# Altium schematic sheet Sheet1.SchDoc
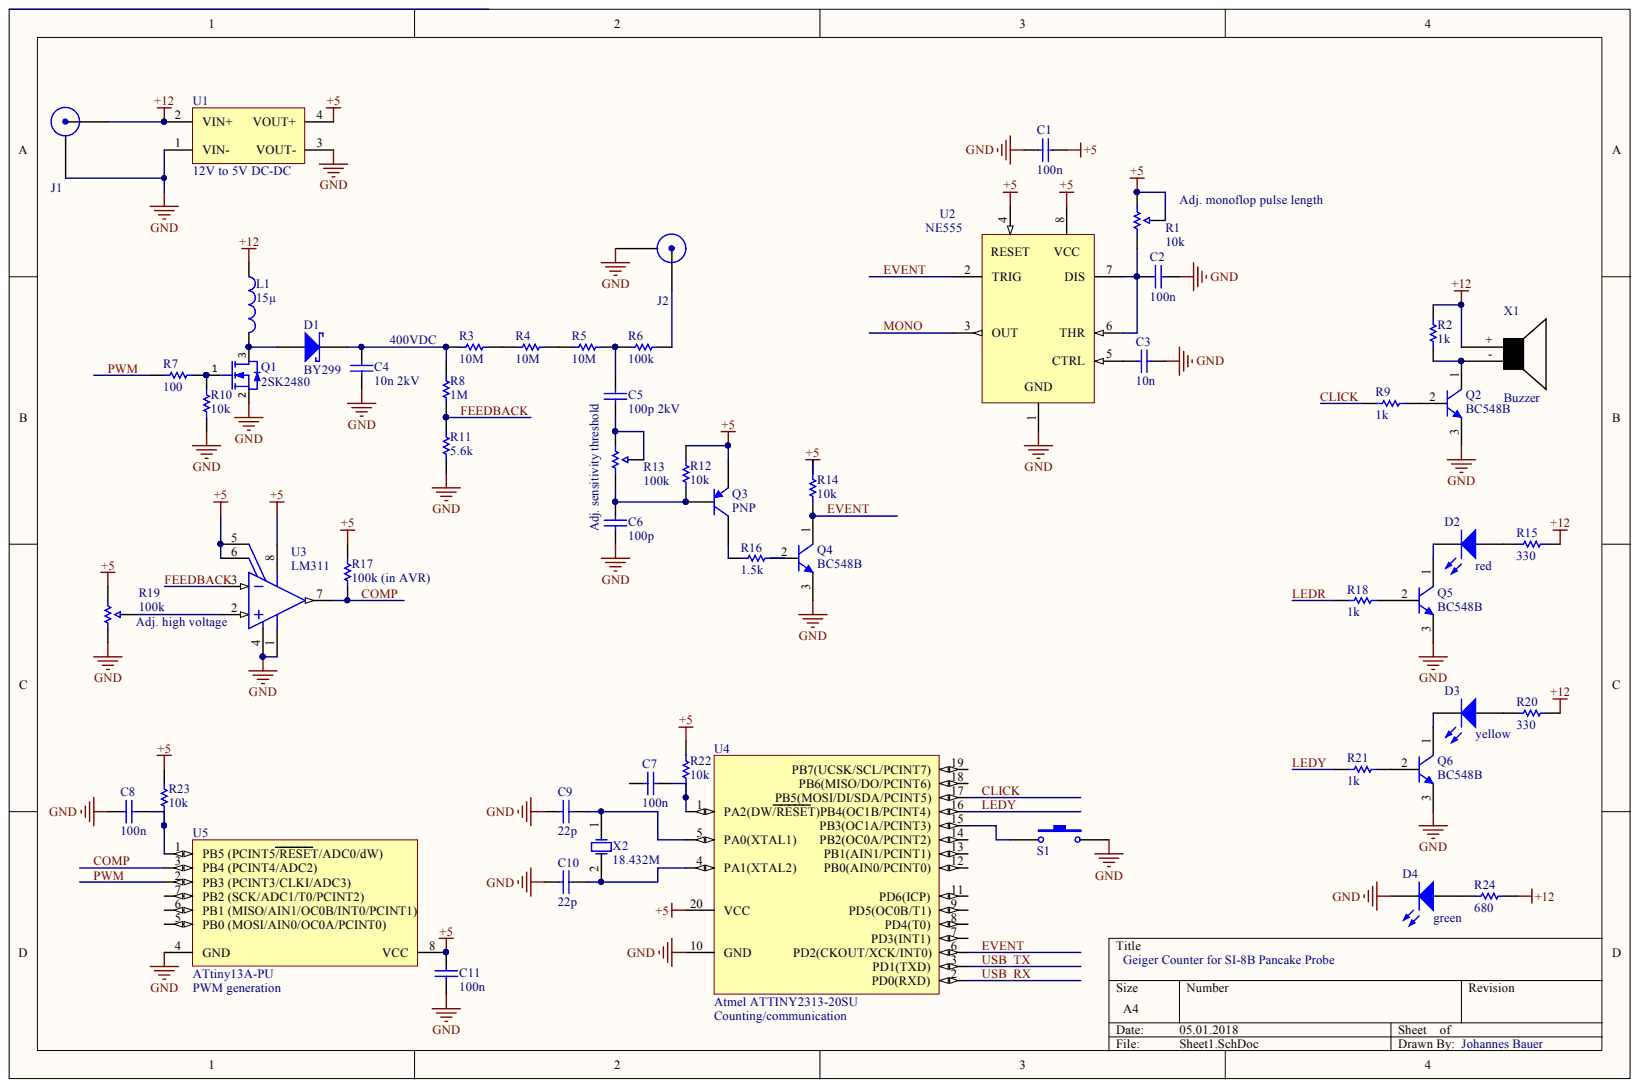
[diagram p0: Sheet1.SchDoc]

## Parts
C1  100n  [CAPC2013X145X50ML20T25]
C2  100n  [CAPC2013X145X50ML20T25]
C3  10n  [CAPC2013X145X50ML20T25]
C4  10n 2kV  [CAPC2013X145X50ML20T25]
C5  100p 2kV  [CAPC2013X145X50ML20T25]
C6  100p  [CAPC2013X145X50ML20T25]
C7  100n  [CAPC2013X145X50ML20T25]
C8  100n  [CAPC2013X145X50ML20T25]
C9  22p  [CAPC2013X145X50ML20T25]
C10  22p  [CAPC2013X145X50ML20T25]
C11  100n  [CAPC2013X145X50ML20T25]
D1  BY299  [PCB-t01urt8kbl9xjuopr1um-1]
D2  red  [PCB-r9altwvwx3huda88quuq-1]
D3  yellow  [PCB-r9altwvwx3huda88quuq-1]
D4  green  [PCB-r9altwvwx3huda88quuq-1]
J1  TE Connectivity 5227699-2  [PCB-578401-1]  (value not drawn)
J2  TE Connectivity 5227699-2  [PCB-578401-1]  (value not drawn)
L1  15µ  [PCB-ilvywpa6bs8jitgkp8xa-1]
Q1  2SK2480  [PCB-2559974-1]
Q2  BC548B  [ONSC-TO-92-3-29-11]
Q3  PNP  [ONSC-SOT-23-3-318-08_V]
Q4  BC548B  [ONSC-TO-92-3-29-11]
Q5  BC548B  [ONSC-TO-92-3-29-11]
Q6  BC548B  [ONSC-TO-92-3-29-11]
R1  10k  [PCB-fwyp7l2njgjc0ljzabsa-1]
R2  1k  [RESC2013X50X30LL20T20]
R3  10M  [RESC2013X50X30LL20T20]
R4  10M  [RESC2013X50X30LL20T20]
R5  10M  [RESC2013X50X30LL20T20]
R6  100k  [RESC2013X50X30LL20T20]
R7  100  [RESC2013X50X30LL20T20]
R8  1M  [RESC2013X50X30LL20T20]
R9  1k  [RESC2013X50X30LL20T20]
R10  10k  [RESC2013X50X30LL20T20]
R11  5.6k  [RESC2013X50X30LL20T20]
R12  10k  [RESC2013X50X30LL20T20]
R13  100k  [PCB-fwyp7l2njgjc0ljzabsa-1]
R14  10k  [RESC2013X50X30LL20T20]
R15  330  [RESC2013X50X30LL20T20]
R16  1.5k  [RESC2013X50X30LL20T20]
R17  100k (in AVR)  [RESC2013X50X30LL20T20]
R18  1k  [RESC2013X50X30LL20T20]
R19  100k  [PCB-fwyp7l2njgjc0ljzabsa-1]
R20  330  [RESC2013X50X30LL20T20]
R21  1k  [RESC2013X50X30LL20T20]
R22  10k  [RESC2013X50X30LL20T20]
R23  10k  [RESC2013X50X30LL20T20]
R24  680  [RESC2013X50X30LL20T20]
S1  (no value)  [SW2_5R6_5R5x3R1]
U1  12V to 5V DC-DC  [PCB-r43aylk1y2or0wdlr2x7-1]
U2  NE555
U3  LM311  [ONSC-SOIC-8-751-07_V]
U4  Atmel ATTINY2313-20SU  [SOIC20-1.27-12.5X7.5MM]
U5  ATtiny13A-PU  [8P3]
X1  Buzzer  [2P-7.6-D12X6.5H]
X2  18.432M  [PCB-fygqjweg5fext21t9isa-1]

## Nets
+5: C1.2 C11.1 Q3.3 R1.1 R1.2 R12.2 R14.2 R17.2 R19.1 R22.2 R23.2 U1.4(VOUT+) U2.4 U2.8 U3.5 U3.6 U3.8 U4.20(VCC) U5.8(VCC)
+12: J1.1 L1.1 R2.2 R15.1 R20.1 R24.1 U1.2(VIN+) X1.+
GND: C1.1 C2.2 C3.2 C4.2 C6.2 C8.2 C9.2 C10.2 C11.2 D4.1 J1.2 J2.2 Q1.2 Q2.3 Q4.3 Q5.3 Q6.3 R10.2 R11.2 R19.3 S1.2 U1.1(VIN-) U1.3(VOUT-) U2.1 U3.1 U3.4 U4.10(GND) U5.4(GND)
CLICK: R9.2 U4.17(PB5(MOSI/DI/SDA/PCINT5))
COMP: R17.1 U3.7 U5.3(PB4_(PCINT4/ADC2))
EVENT: Q4.1 R14.1 U2.2(TRIG) U4.6(PD2(CKOUT/XCK/INT0))
FEEDBACK: R8.2 R11.1 U3.3
LEDR: R18.2
LEDY: R21.2 U4.16(PB4(OC1B/PCINT4))
MONO: U2.3(OUT)
PWM: R7.2 U5.2(PB3_(PCINT3/CLKI/ADC3))
USB_RX: U4.2(PD0(RXD))
USB_TX: U4.3(PD1(TXD))
NetC2_1: C2.1 R1.3 U2.6(THR) U2.7(DIS)
NetC3_1: C3.1 U2.5(CTRL)
NetC4_1: C4.1 D1.2 R3.2 R8.1
NetC5_1: C5.1 R5.1 R6.2
NetC5_2: C5.2 R13.1 R13.2
NetC6_1: C6.1 Q3.2 R12.1 R13.3
NetC7_1: C7.1 R22.1 U4.1(PA2(DW/RESET))
NetC8_1: C8.1 R23.1 U5.1(PB5_(PCINT5/RESET/ADC0/dW))
NetC9_1: C9.1 U4.5(PA0(XTAL1)) X2.1
NetC10_1: C10.1 U4.4(PA1(XTAL2)) X2.2
NetD1_1: D1.1 L1.2 Q1.3
NetD2_1: D2.1 Q5.1
NetD2_2: D2.2 R15.2
NetD3_1: D3.1 Q6.1
NetD3_2: D3.2 R20.2
NetD4_2: D4.2 R24.2
NetJ2_1: J2.1 R6.1
NetQ1_1: Q1.1 R7.1 R10.1
NetQ2_1: Q2.1 R2.1 X1.-
NetQ2_2: Q2.2 R9.1
NetQ3_1: Q3.1 R16.2
NetQ4_2: Q4.2 R16.1
NetQ5_2: Q5.2 R18.1
NetQ6_2: Q6.2 R21.1
NetR3_1: R3.1 R4.2
NetR4_1: R4.1 R5.2
NetR19_2: R19.2 U3.2
NetS1_1: S1.1 U4.15(PB3(OC1A/PCINT3))
N00011:

Not connected: C7 2; U4 7(PD3(INT1)) 8(PD4(T0)) 9(PD5(OC0B/T1)) 11(PD6(ICP)) 12(PB0(AIN0/PCINT0)) 13(PB1(AIN1/PCINT1)) 14(PB2(OC0A/PCINT2)) 18(PB6(MISO/DO/PCINT6)) 19(PB7(UCSK/SCL/PCINT7)); U5 5(PB0_(MOSI/AIN0/OC0A/PCINT0)) 6(PB1_(MISO/AIN1/OC0B/INT0/PCINT1)) 7(PB2_(SCK/ADC1/T0/PCINT2))

## Library data of the parts
C1-C11 = C0805C224J5RACTU: Multilayer Ceramic Capacitors MLCC - SMD/SMT 50volts 0.22uF X7R 5%; Capacitance: 2.2e-07 F; Lead-Free Status: R; Voltage Rating (DC): 50.0 V; Capacitance Tolerance: ±5%; Case/Package: 0805; Component Kind: Standard; Dielectric Characteristic: X7R; Dielectric Material: Ceramic; Library Name: Octopart library; Mounting Style: Surface Mount; OctopartID: dc57145de2800390; Octopart Url: https://octopart.com/c0805c224j5ractu-kemet-16784305; RoHS: Compliant
D1 = MSS2P3-M3/89A: MSS2P3 Series 30 V 2 A Surface Mount Schottky Barrier Rectifier - MicroSMP; Component Kind: Standard; Library Name: Octopart library; OctopartID: ce170593b09f11d0
D2-D4 = SML-D12D8WT86: SML-D12 Series Orange 0603 Diffused 100 mcd 2.2V Surface Mount LED; Forward Voltage: 2.20 V; Luminous Intensity: 100 mcd; Case/Package: 0603; Color: Orange; Component Kind: Standard; Library Name: Octopart library; Manufacturer Part Number: SML-D12D8WT86; OctopartID: a47e6df945417bb9; Octopart URL: http://octopart.com/sml-d12d8wt86-rohm-9290841; Packaging: Cut Tape (CT)
J1 J2 = 5227699-2: CONN JACK BNC VERT 50 OHM PCB AU; ELV Compliant: Compliant; Gender: Male; Lead-Free Status: Lead Free; Component Kind: Standard; Contact Material: Phosphor Bronze; Contact Plating: Gold; Library Name: Octopart library; Lifecycle Status: Active; OctopartID: aab361e385ae7574; Octopart Url: https://octopart.com/5227699-2-te+connectivity-39455355; Orientation: Vertical; Packaging: Tube; REACH SVHC Compliance: No SVHC; RoHS: Compliant
L1 = ELJLC100KF: Ind Power Chip Molded Wirewound 10uH 10% 1MHz Ferrite 240mA 1008 T/R; Inductance: 10.0 µH; Component Kind: Standard; Library Name: Octopart library; Lifecycle Status: Not Listed by Manufacturer; Manufacturer Part Number: ELJLC100KF; Number of Pins: 2; OctopartID: e8262003ce8837e1; Packaging: Cut Tape (CT); REACH SVHC Compliance: No SVHC; RoHS: Compliant
Q1 = CMP-2559974-6: Trans MOSFET N-CH 25V 5.8A 3-Pin SOT-23 T/R; Part Family: IRFML8244; Lead-Free Status: Lead Free; Polarity: N-Channel; Power Dissipation: 1.25 W; Component Kind: Standard; Library Name: CommunityVault; Lifecycle Status: Active; Mounting Style: Surface Mount; Number of Pins: 3; OctopartID: 10b1d89ad6afcf76; Octopart Url: https://octopart.com/irfml8244trpbf-international+rectifier-18998136; Packaging: Cut Tape (CT); REACH SVHC Compliance: No SVHC; RoHS: Compliant
Q2 Q4-Q6 = BC548B: Amplifier Transistor, NPN Silicon, 3-Pin TO-92, Bulk Box; Current Rating: 0.1 A; Lead-Free Status: Contains Lead; Voltage Rating (DC): 30.0 V; Case/Package: TO-92; Component Kind: Standard; Library Name: Octopart library; Lifecycle Status: Not Listed by Manufacturer; Mounting Style: Through Hole; OctopartID: fd5934472be4229e; Octopart Url: https://octopart.com/bc548b-on+semiconductor-1373147; Packaging: Bulk; RoHS: Compliant
Q3 = BC558: Transistor PNP BC558 PHILIPS miliampere=100 SOT-23; Breakdown Voltage [Collector to Emitter]: 30.0 V; Component Kind: Standard; Library Name: Octopart library
R1 R13 R19 = PTV09A-4015F-B104: Res Carbon Film POT 100K Ohm 20% 1/20W 1(Elec)/1(Mech)Turn 6mm (11.4 X 12.65 X 18.8mm) Pin Bracket Mount/Through Hole; Power Rating: 50.0 mW; Component Kind: Standard; Library Name: Octopart library; Manufacturer Part Number: PTV09A-4015F-B104; OctopartID: 5909901fd92786ce; Octopart URL: http://octopart.com/ptv09a-4015f-b104-bourns-1877320; Resistance: 100K; Resistance Tolerance: ±20%; RoHS: Compliant
R2-R6 R8 R9 R11 R12 R14-R18 R20-R24 = CRCW080518K0FKEA: RES SMD 18K OHM 1% 1/8W 0805; Case/Package (SI): 2012; Lead-Free Status: Lead Free; Power Rating: 0.125 W; Resistance: 18000.0 Ω; Temperature Coefficient: 100.0 ppm/°C; Voltage Rating (DC): 150.0 V; Case/Package: 0805; Component Kind: Standard; Library Name: Octopart library; Mounting Style: Surface Mount; Number of Pins: 2; OctopartID: e74b2c1ee574388c; Octopart Url: https://octopart.com/crcw080518k0fkea-vishay-39790425; REACH SVHC Compliance: No SVHC; Resistance Tolerance: ±1%; RoHS: Compliant; Size-Height: 0.00045 m; Size-Length: 0.002 m; Size-Width: 0.00125 m
R7 R10 = CMP-1857865-3: RES SMD 18K OHM 1% 1/8W 0805; Case/Package (SI): 2012; Lead-Free Status: Lead Free; Power Rating: 0.125 W; Resistance: 18000.0 Ω; Temperature Coefficient: 100.0 ppm/°C; Voltage Rating (DC): 150.0 V; Case/Package: 0805; Component Kind: Standard; Library Name: CommunityVault; Mounting Style: Surface Mount; Number of Pins: 2; OctopartID: e74b2c1ee574388c; Octopart Url: https://octopart.com/crcw080518k0fkea-vishay-39790425; REACH SVHC Compliance: No SVHC; Resistance Tolerance: ±1%; RoHS: Compliant; Size-Height: 0.00045 m; Size-Length: 0.002 m; Size-Width: 0.00125 m
S1 = KSS221GLFS: Switch Tactile N.O. SPST Rectangular Button Gull Wing 0.05A 32VDC 1VA 100000Cycles 2N SMD T/R; Actuator Type: Standard; Contact Current Rating: 50.0 mA; Contacts Type: SPST-NO; Lead-Free Status: Lead Free; Component Kind: Standard; Contact Plating: Silver; Library Name: Octopart library; Manufacturer Part Number: KSS221GLFS; Mounting Style: Surface Mount; OctopartID: e9ed20f5b4b9362a; Octopart Url: http://octopart.com/kss221glfs-c%26k+components-1210418; Orientation: Right Angle; Packaging: Reel; RoHS: Compliant; Seller Landing  Url: https://octopart-clicks.com/click/track?country=US&ak=8157f166&sig=01bc541&sid=1106&ppid=1210418&vpid=37432965&ct=offers; Size-Length: 7.00 mm; Size-Width: 3.10 mm
U1 = CMP-a76c6e8d16a778ab-1: RO Series 1 W 3.3 V Single Output ECONOLINE DC/DC-Converter - SIP-4; Manufacturer Part Number: RO-053.3S; Input Voltage (DC): 5.0 V; Lead-Free Status: Lead Free; Component Kind: Standard; Library Name: CommunityVault; Lifecycle Status: Active; OctopartID: a76c6e8d16a778ab; Octopart URL: http://octopart.com/ro-053.3s-recom+power-15439021; REACH SVHC Compliance: No SVHC; RoHS: Compliant; Size-Height: 0.01 m; Size-Width: 0.006 m
U2 = NE555P: Peripheral circuit; astable, monostable, RC timer; 10 V; 500kHz; Current Rating: 10.0 A; Lead-Free Status: Lead Free; Quiescent Current: 2.00 mA; Supply Voltage (DC): 4.50 V (min); Case/Package: PDIP; Component Kind: Standard; Frequency: 500 kHz; Library Name: Octopart library; Lifecycle Status: Active; Manufacturer Part Number: NE555P; Mounting Style: Through Hole; Number of Pins: 8; OctopartID: 8f734534f03350b4; Octopart URL: http://octopart.com/ne555p-texas+instruments-55400998; Packaging: Tube; REACH SVHC Compliance: No SVHC; RoHS: Compliant
U3 = LM311DR2G: Single Comparator, 5 to 30 V, 0 to 70 degC, 8-Pin SOIC, Pb-Free, Tape and Reel; Lead-Free Status: Lead Free; Number of Channels: 1; Quiescent Current: 0.0024 A; Case/Package: SOIC; Component Kind: Standard; Halogen Free Status: Halogen Free; Library Name: Octopart library; Lifecycle Status: Active; Mounting Style: Surface Mount; Number of Pins: 8; OctopartID: 3430fd3c9bbcebf7; Octopart Url: https://octopart.com/lm311dr2g-on+semiconductor-428778; Packaging: Cut Tape (CT); RoHS: Compliant
U4 = ATTINY2313-20SU: ATtiny Series 20 MHz 2 KB Flash 128 B SRAM 8-Bit Microcontroller - SOIC-20; Access Time: 2e-05 s; Clock Speed: 20000000.0 Hz; Core Architecture: AVR; FLASH Memory Size: 2048 B; Lead-Free Status: Lead Free; Number of Bits: 8; Number of I/O Pins: 18; RAM Memory Size: 128 B; Supply Voltage (DC): 5.0 V; Case/Package: SOIC; Component Kind: Standard; Library Name: Octopart library; Manufacturer Part Number: ATTINY2313-20SU; Mounting Style: Surface Mount; Number of Pins: 20; OctopartID: eb1e20750bcd34d9; Octopart Url: http://octopart.com/attiny2313-20su-atmel-39962037; Packaging: Tube; REACH SVHC Compliance: No SVHC; RoHS: Compliant; Seller Landing  Url: https://octopart-clicks.com/click/track?country=US&ak=8157f166&sig=07b437c&sid=5489&ppid=39962037&vpid=115080005&ct=offers
U5 = ATTINY13A-PU: 8-bit AVR Microcontroller, 1.8-5.5V, 20MHz, 1KB Flash, 64 Bytes EEPROM, 64 Bytes SRAM, 8-pin PDIP, Industrial Grade (-40°C to 85°C), Pb-Free; Clock Speed: 20000000.0 Hz; FLASH Memory Size: 1024 B; Lead-Free Status: Lead Free; RAM Memory Size: 60 B; Component Kind: Standard; Library Name: Octopart library; Mounting Style: Through Hole; Number of Pins: 8; OctopartID: 6524aea05828bae3; Octopart Url: https://octopart.com/attiny13a-pu-atmel-42866794; REACH SVHC Compliance: No SVHC; RoHS: Compliant
X1 = PS1240P02BT: Buzzer 12mm; Component Kind: Standard; Library Name: Octopart library
X2 = ABS06-32.768KHZ-9-1-T: Crystal 0.032768MHz }10ppm (Tol) 9pF 90000Ohm 2-Pin CSMD T/R; Load Capacitance: 9.00 pF; Component Kind: Standard; Library Name: Octopart library; Manufacturer Part Number: ABS06-32.768KHZ-9-1-T; Number of Pins: 2; RoHS: Compliant
